# Altium schematic sheet eMMC.SchDoc(eMMC)
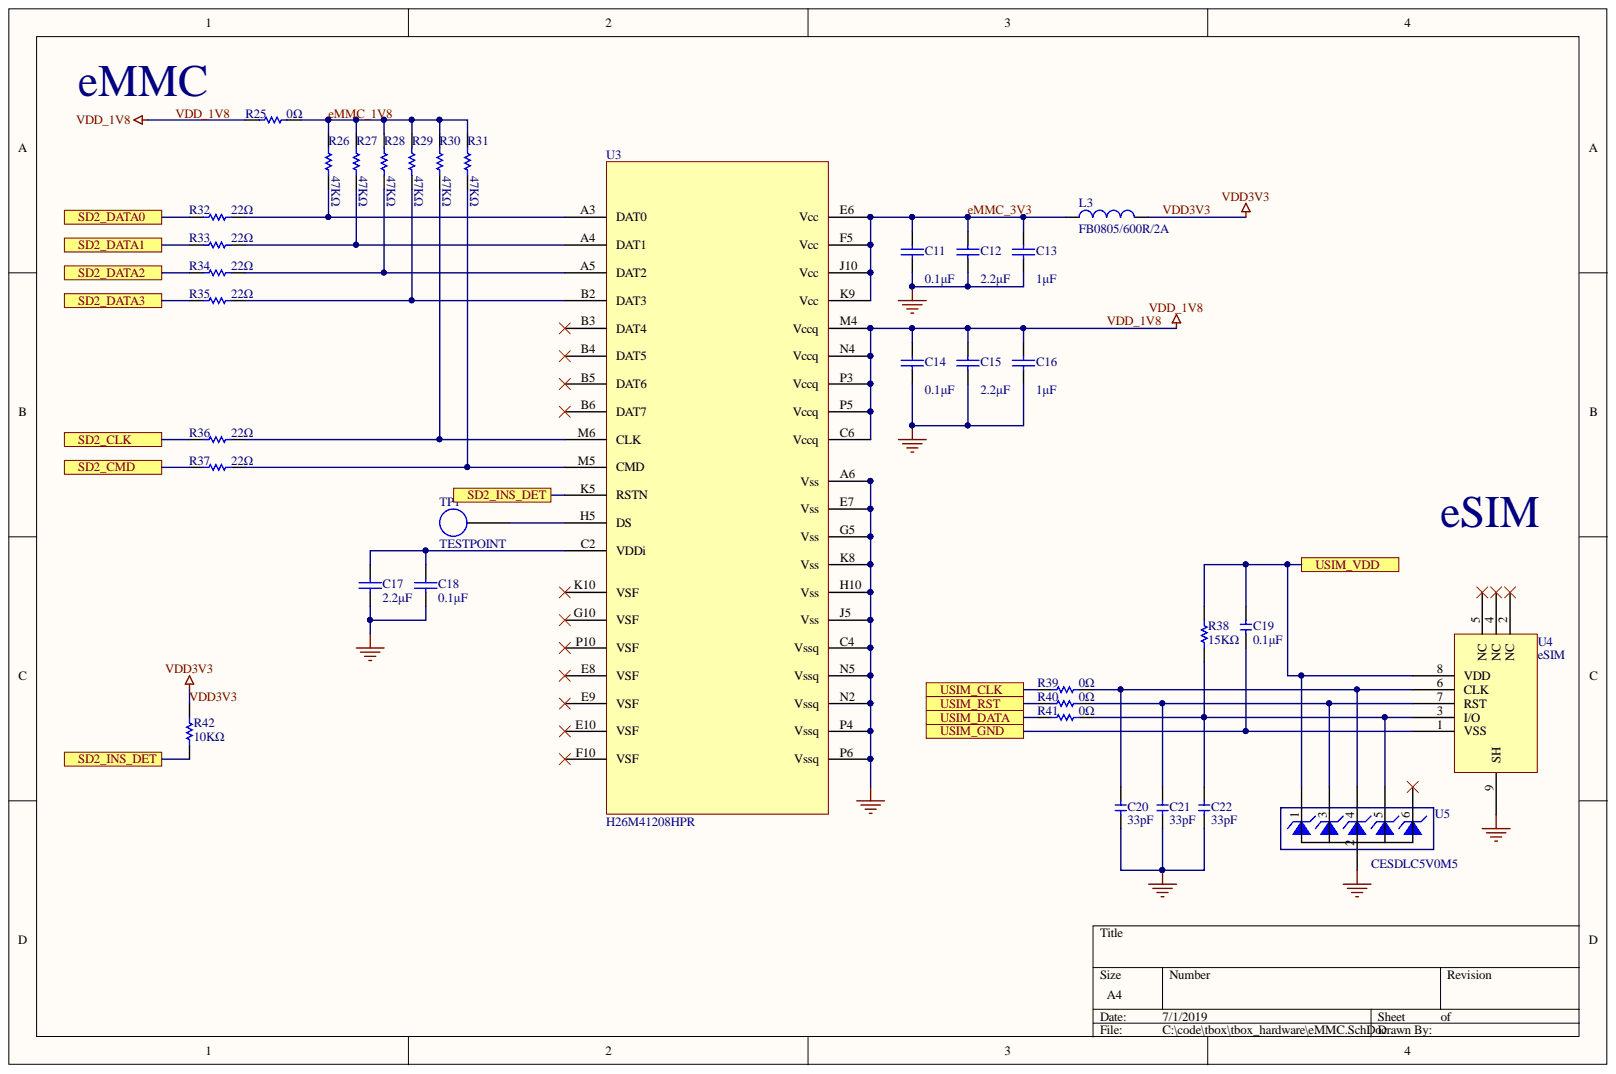
[diagram p0: eMMC.SchDoc]

## Parts
C11  0.1μF  [C0402]
C12  2.2μF  [C0402]
C13  1μF  [C0402]
C14  0.1μF  [C0402]
C15  2.2μF  [C0402]
C16  1μF  [C0402]
C17  2.2μF  [C0402]
C18  0.1μF  [C0402]
C19  0.1μF  [C0402]
C20  33pF  [C0402]
C21  33pF  [C0402]
C22  33pF  [C0402]
L3  FB0805/600R/2A  [0805_L]
R25  0Ω  [R0603]
R26  47KΩ  [R0402]
R27  47KΩ  [R0402]
R28  47KΩ  [R0402]
R29  47KΩ  [R0402]
R30  47KΩ  [R0402]
R31  47KΩ  [R0402]
R32  22Ω  [R0402]
R33  22Ω  [R0402]
R34  22Ω  [R0402]
R35  22Ω  [R0402]
R36  22Ω  [R0402]
R37  22Ω  [R0402]
R38  15KΩ  [R0402]
R39  0Ω  [R0402]
R40  0Ω  [R0402]
R41  0Ω  [R0402]
R42  10KΩ  [R0402]
TP1  TESTPOINT  [TP]
U3  H26M41208HPR  [BGA153C50P14X14_FIX]
U4  eSIM  [ESIM]
U5  CESDLC5V0M5  [SOT563]

## Ports
SD2_CLK, SD2_CMD, SD2_DATA0, SD2_DATA1, SD2_DATA2, SD2_DATA3, SD2_INS_DET, USIM_CLK, USIM_DATA, USIM_GND, USIM_RST, USIM_VDD

## Nets
GND: C11.1 C12.1 C13.1 C14.1 C15.1 C16.1 C17.1 C18.1 C20.1 C21.1 C22.1 U3.A6(Vss) U3.C4(Vssq) U3.E7(Vss) U3.G5(Vss) U3.H10(Vss) U3.J5(Vss) U3.K8(Vss) U3.N2(Vssq) U3.N5(Vssq) U3.P4(Vssq) U3.P6(Vssq) U4.9(SH) U5.2
eMMC_1V8: R25.2 R26.2 R27.2 R28.2 R29.2 R30.2 R31.2
eMMC_3V3: C11.2 C12.2 C13.2 L3.1 U3.E6(Vcc) U3.F5(Vcc) U3.J10(Vcc) U3.K9(Vcc)
SD2_CLK: R36.1 ; port SD2_CLK
SD2_CMD: R37.1 ; port SD2_CMD
SD2_DATA0: R32.1 ; port SD2_DATA0
SD2_DATA1: R33.1 ; port SD2_DATA1
SD2_DATA2: R34.1 ; port SD2_DATA2
SD2_DATA3: R35.1 ; port SD2_DATA3
SD2_INS_DET: R42.2 U3.K5(RSTN) ; port SD2_INS_DET
USIM_CLK: R39.2 ; port USIM_CLK
USIM_DATA: R41.2 ; port USIM_DATA
USIM_GND: C19.1 U4.1(VSS) ; port USIM_GND
USIM_RST: R40.2 ; port USIM_RST
USIM_VDD: C19.2 R38.1 U4.8(VDD) U5.1 ; port USIM_VDD
VDD3V3: L3.2 R42.1
VDD_1V8: C14.2 C15.2 C16.2 R25.1 U3.C6(Vccq) U3.M4(Vccq) U3.N4(Vccq) U3.P3(Vccq) U3.P5(Vccq)
NetC17_2: C17.2 C18.2 U3.C2(VDDi)
NetC20_2: C20.2 R39.1 U4.6(CLK) U5.4
NetC21_2: C21.2 R40.1 U4.7(RST) U5.3
NetC22_2: C22.2 R38.2 R41.1 U4.3(I/O) U5.5
NetR26_1: R26.1 R32.2 U3.A3(DAT0)
NetR27_1: R27.1 R33.2 U3.A4(DAT1)
NetR28_1: R28.1 R34.2 U3.A5(DAT2)
NetR29_1: R29.1 R35.2 U3.B2(DAT3)
NetR30_1: R30.1 R36.2 U3.M6(CLK)
NetR31_1: R31.1 R37.2 U3.M5(CMD)
NetTP1_1: TP1.1 U3.H5(DS)

Not connected: U3 B3(DAT4) B4(DAT5) B5(DAT6) B6(DAT7) E8(VSF) E9(VSF) E10(VSF) F10(VSF) G10(VSF) K10(VSF) P10(VSF); U4 2(NC) 4(NC) 5(NC); U5 6

## Library data of the parts
C11-C18 = Cap: Capacitor; Simulation: CAP; Signal Integrity: Cap; Published: 8-Jun-2000; PackageReference: RAD-0.3; PackageDescription: Radial Cap, Thru-Hole; 2 Leads; 0.3 in Pin Spacing; Library Name: Miscellaneous Devices.SchLib; LatestRevisionNote: Re-released for DXP Platform.; LatestRevisionDate: 17-Jul-2002; Component Kind: Standard; Publisher: Altium Limited
  C11 C14 C18: Value: 0.1μF
  C12 C15 C17: Value: 2.2μF
  C13 C16: Value: 1μF
C19-C22 = Cap Semi: Capacitor (Semiconductor SIM Model); Simulation: CAP; Signal Integrity: Cap Semi; Published: 8-Jun-2000; PackageReference: C1206; PackageDescription: Chip Capacitor; Library Name: Miscellaneous Devices.SchLib; LatestRevisionNote: IPC-7351 Footprint Added.; LatestRevisionDate: 29-May-2009; Component Kind: Standard; Publisher: Altium Limited
  C19: Value: 0.1μF
  C20-C22: Value: 33pF
L3 = Inductor: Code_IEC: 1005; Value: FB0805/600R/2A; Simulation: INDUCTOR; Signal Integrity: Inductor; Published: 8-Jun-2000; PackageReference: 0402-A; PackageDescription: Chip Inductor; Library Name: Miscellaneous Devices.SchLib; LatestRevisionNote: IPC-7351 Footprint Added.; LatestRevisionDate: 29-May-2009; Description: Inductor; Component Kind: Standard; Code_JEITA: 0402; Publisher: Altium Limited
R25-R42 = Res1: Resistor; Simulation: RESISTOR; Signal Integrity: Res; Published: 8-Jun-2000; PackageReference: AXIAL-0.3; PackageDescription: Axial Device, Thru-Hole; 2 Leads; 0.3 in Pin Spacing; Library Name: Miscellaneous Devices.SchLib; LatestRevisionNote: Re-released for DXP Platform.; LatestRevisionDate: 17-Jul-2002; Component Kind: Standard; Publisher: Altium Limited
  R25 R39-R41: Value: 0Ω
  R26-R31: Value: 47KΩ
  R32-R37: Value: 22Ω
  R38: Value: 15KΩ
  R42: Value: 10KΩ
U3 = H26M41208HPR: e.MMC 5.1 Specification compatibility; Library Name: Miscellaneous Devices.SchLib; Component Kind: Standard
U5 = CESDLC5V0M5: Low Capacitance  Quad Array for  ESD Protection; Library Name: Miscellaneous Devices.SchLib; Component Kind: Standard
TP1 = TestPoint: Library Name: Miscellaneous Devices.SchLib; Component Kind: Standard
U4 = eSIM: Library Name: Miscellaneous Devices.SchLib; Component Kind: Standard
